# Altium schematic sheet [11]-MAIN_POWER.SchDoc([11]-MAIN_POWER)
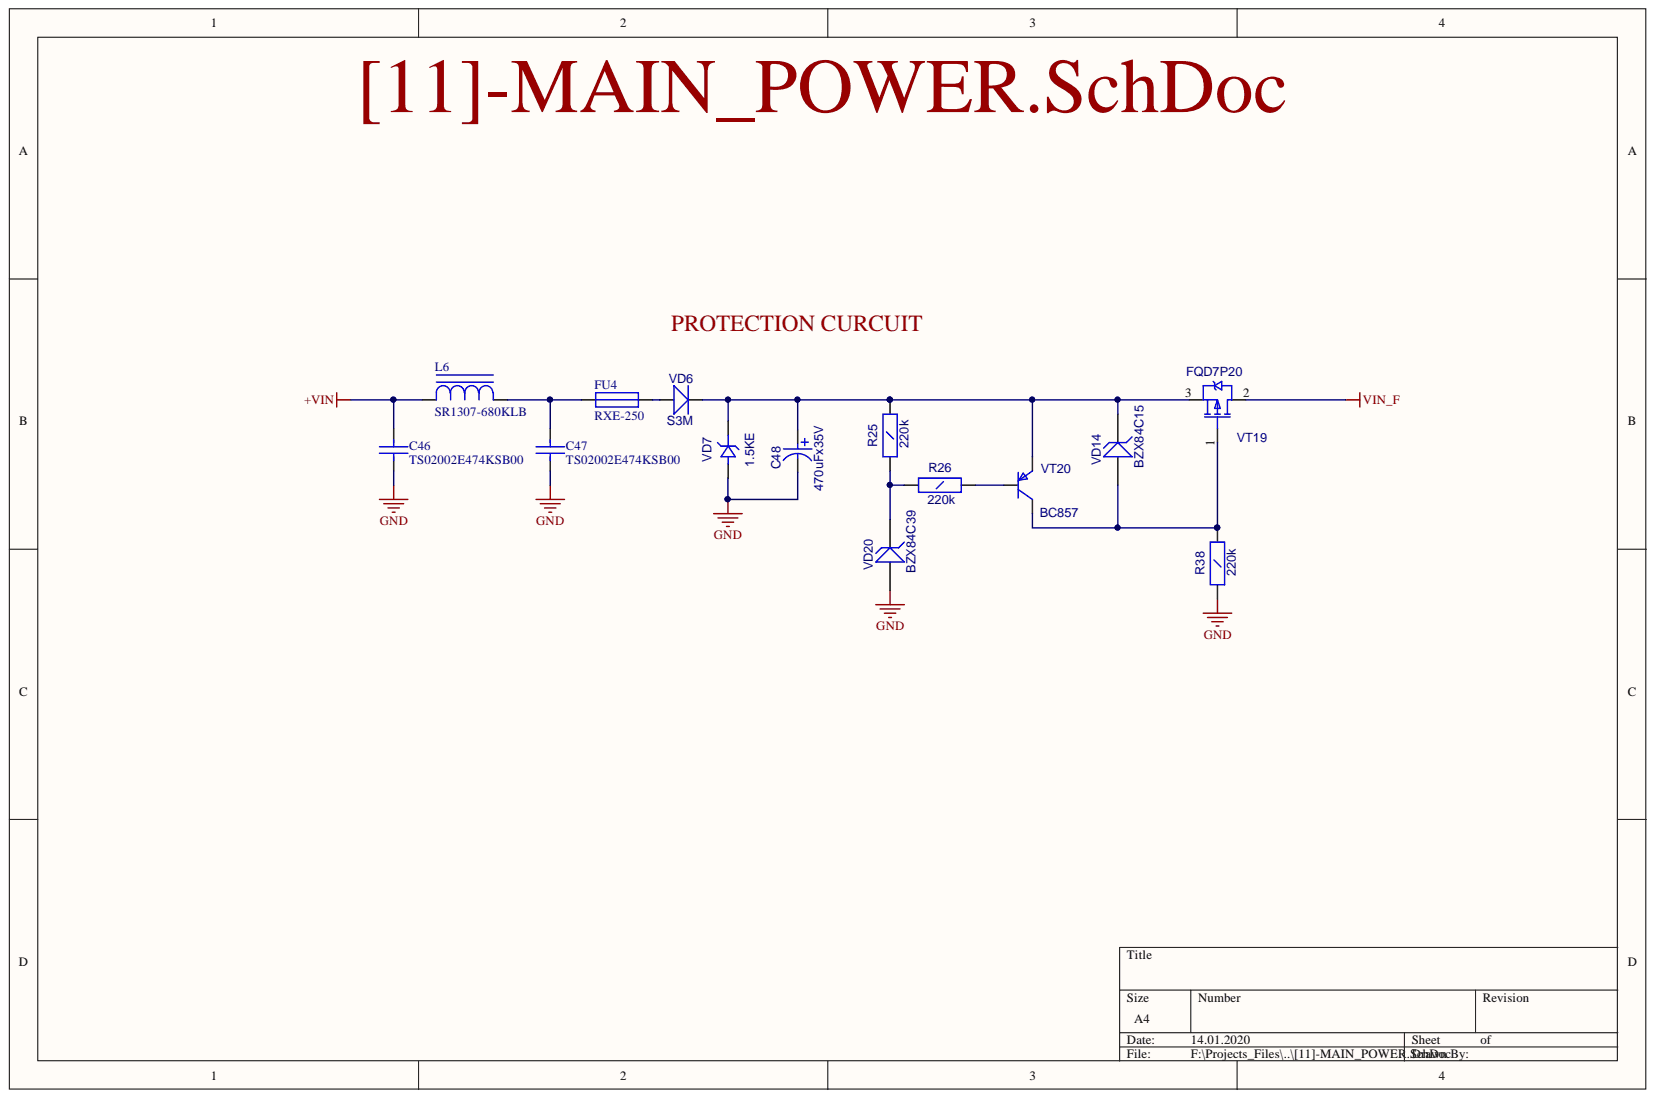
[diagram p0: [11]-MAIN_POWER.SchDoc]

## Parts
C46  TS02002E474KSB00  [CAP_HV_13x5]
C47  TS02002E474KSB00  [CAP_HV_13x5]
C48  value 470uFx35V  [CC16X10_CAP0.5(1)(Primary)]  (hidden comment: CAP0.5(1))
FU4  RXE-250  [RXE-250_FLAT]
L6  SR1307-680KLB  [SR1307]
R25  220k  [RC1206]
R26  220k  [RC1206]
R38  220k  [RC1206]
VD6  S3M  [SMC]
VD7  1.5KE  [DO-201]
VD14  BZX84C15  [SOT-23(123)]
VD20  BZX84C39  [SOT-23(123)]
VT19  FQD7P20  [D-PAK(TO-252AA)]
VT20  BC857  [SOT-23(123)]

## Nets
+VIN: C46.2 L6.1
GND: C46.1 C47.1 C48.1 R38.1 VD7.1 VD20.1
VIN_F: VT19.2
NetC47_2: C47.2 FU4.1 L6.2
NetC48_2: C48.2 R25.2 VD6.2 VD7.2 VD14.3 VT19.3 VT20.2
NetFU4_2: FU4.2 VD6.1
NetR25_1: R25.1 R26.1 VD20.3
NetR26_2: R26.2 VT20.1
NetR38_2: R38.2 VD14.1 VT19.1 VT20.3

## Library data of the parts
C46 C47 = CAP_HV_13x5: Value: TS02002E474KSB00; Component Kind: Standard; Library Name: fizik_lib.SchLib
L6 = SR1307: Power inductor; Value: SR1307-680KLB; Component Kind: Standard; Library Name: fizik_lib.SchLib
R25 R26 R38 = RC1206: Value: 220k; Description: RC1206; Component Kind: Standard; Library Name: fizik_lib.SchLib
VD6 = S3A: Schottky Rectifier; Value: S3M; Component Kind: Standard; Library Name: fizik_lib.SchLib
VD14 VD20 = BZX84: Zener Voltage Regulator Diode; Component Kind: Standard; Library Name: fizik_lib.SchLib
  VD14: Value: BZX84C15
  VD20: Value: BZX84C39
VT19 = IRFR9220: HEXFET Power MOSFET; OriginalName: IRLML5103; ComponentField7: Published 8-Jun-2000; Value: FQD7P20; Component Kind: Standard; Library Name: gprs_modem_2.SchLib
VT20 = BC857B: PNP 100ma transistor; OriginalName: BC846BLT1; ComponentField7: Published 29-Sep-2000; Value: BC857; Simulation: BC846BLT1; Component Kind: Standard; Library Name: gprs_modem_2.SchLib
C48 = CAP0.5(1): Description: CAP0.5(1); Component Kind: Standard; Library Name: gprs_modem_2.SchLib
FU4 = RXE-250: Value: RXE-250; Component Kind: Standard; Library Name: fizik_lib.SchLib
VD7 = 1.5KE: Description: 1.5KE; Component Kind: Standard; Library Name: fizik_lib.SchLib
